# Altium schematic sheet Sheet1.SchDoc(Sheet1)
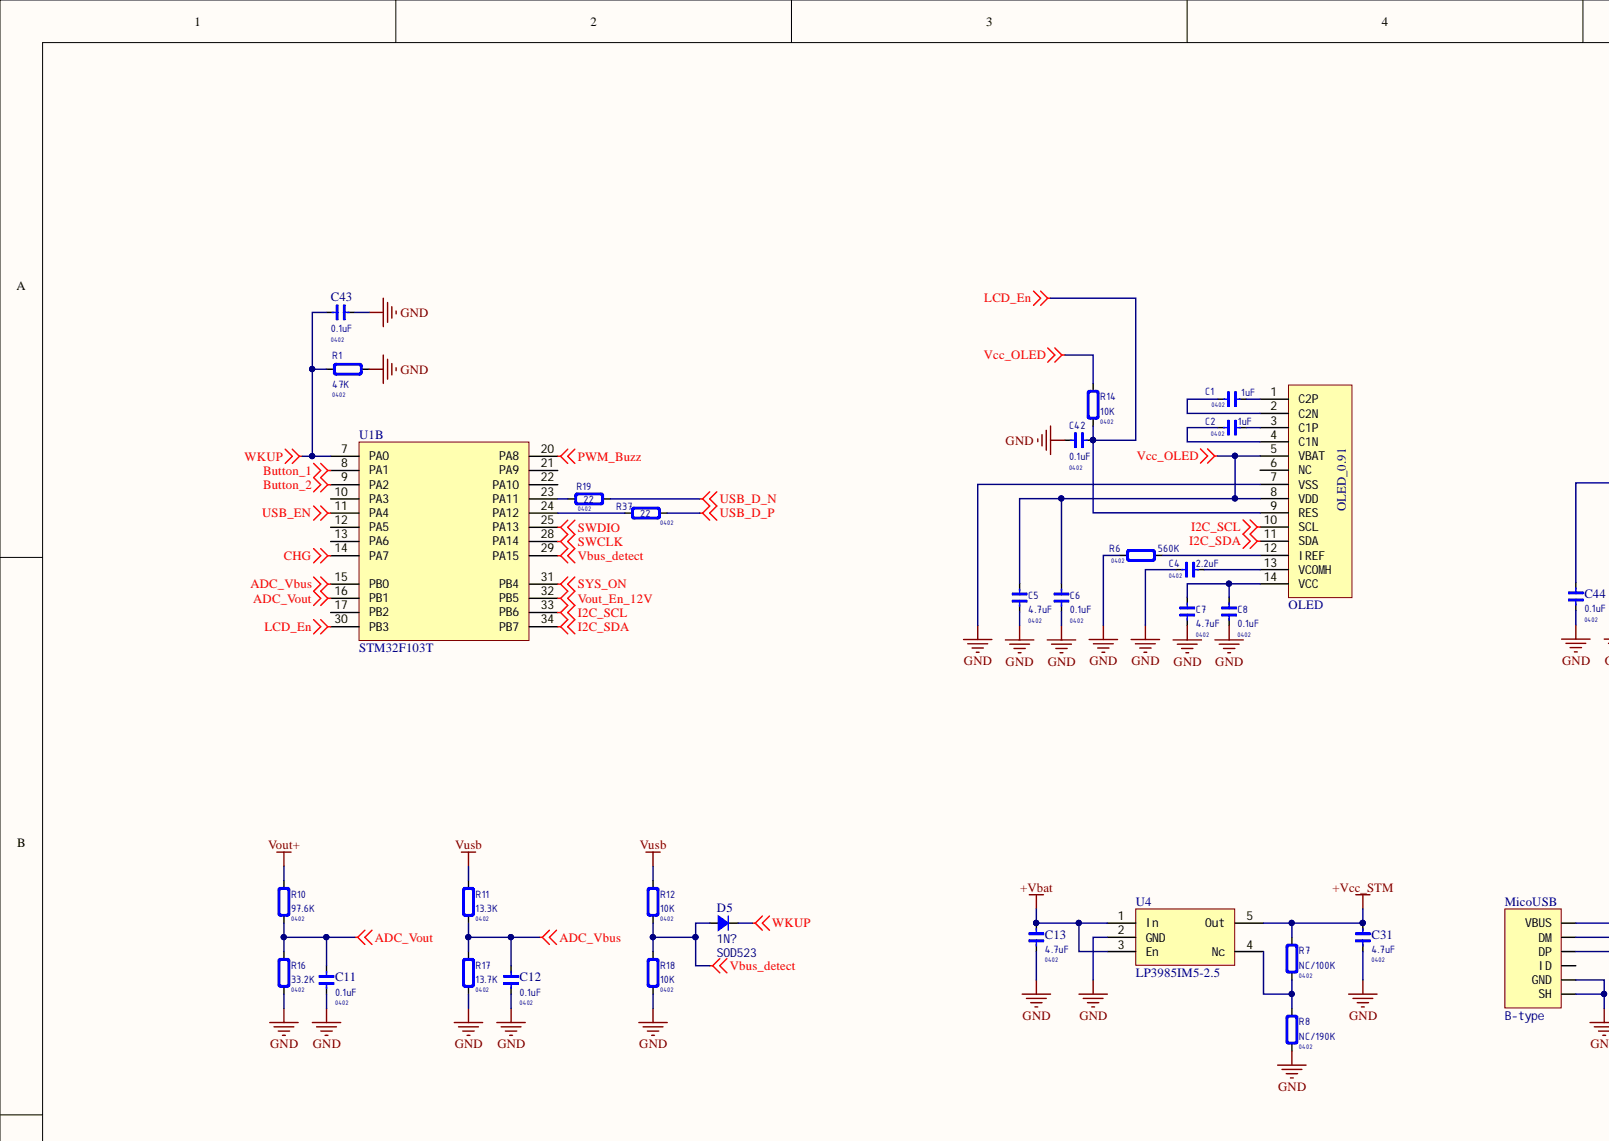
[diagram p0: Sheet1.SchDoc, top left]
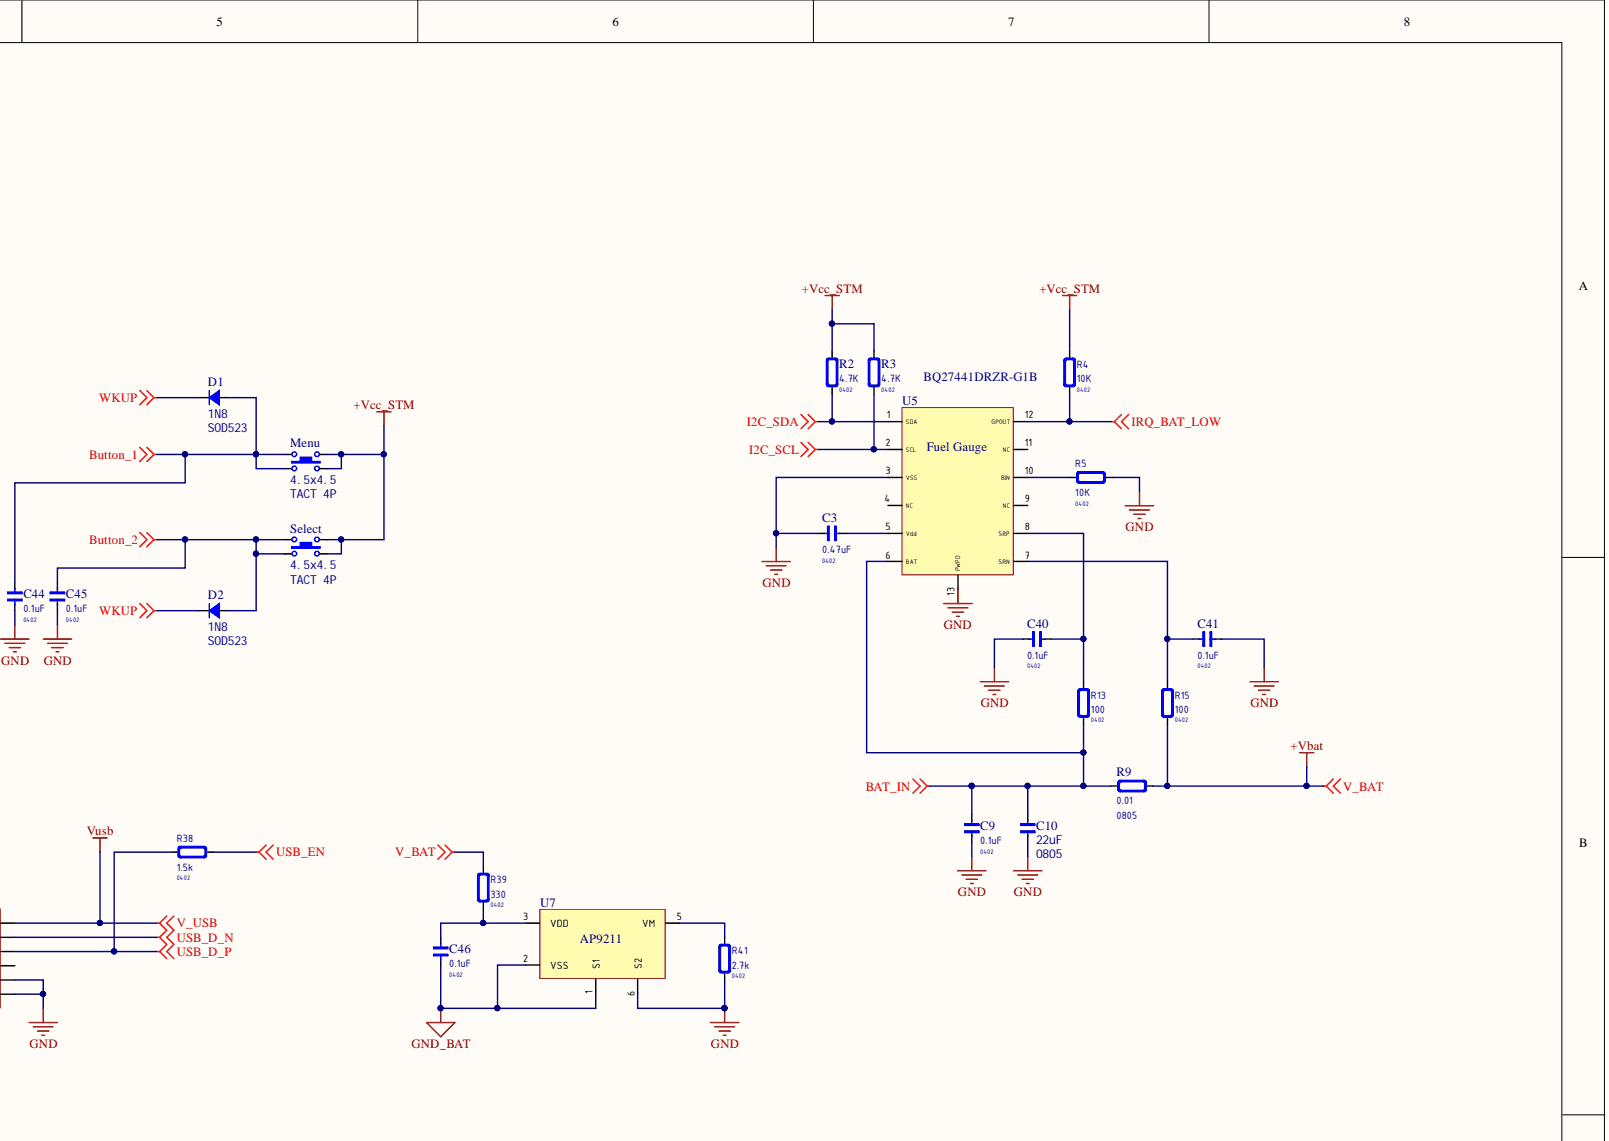
[diagram p1: Sheet1.SchDoc, top right]
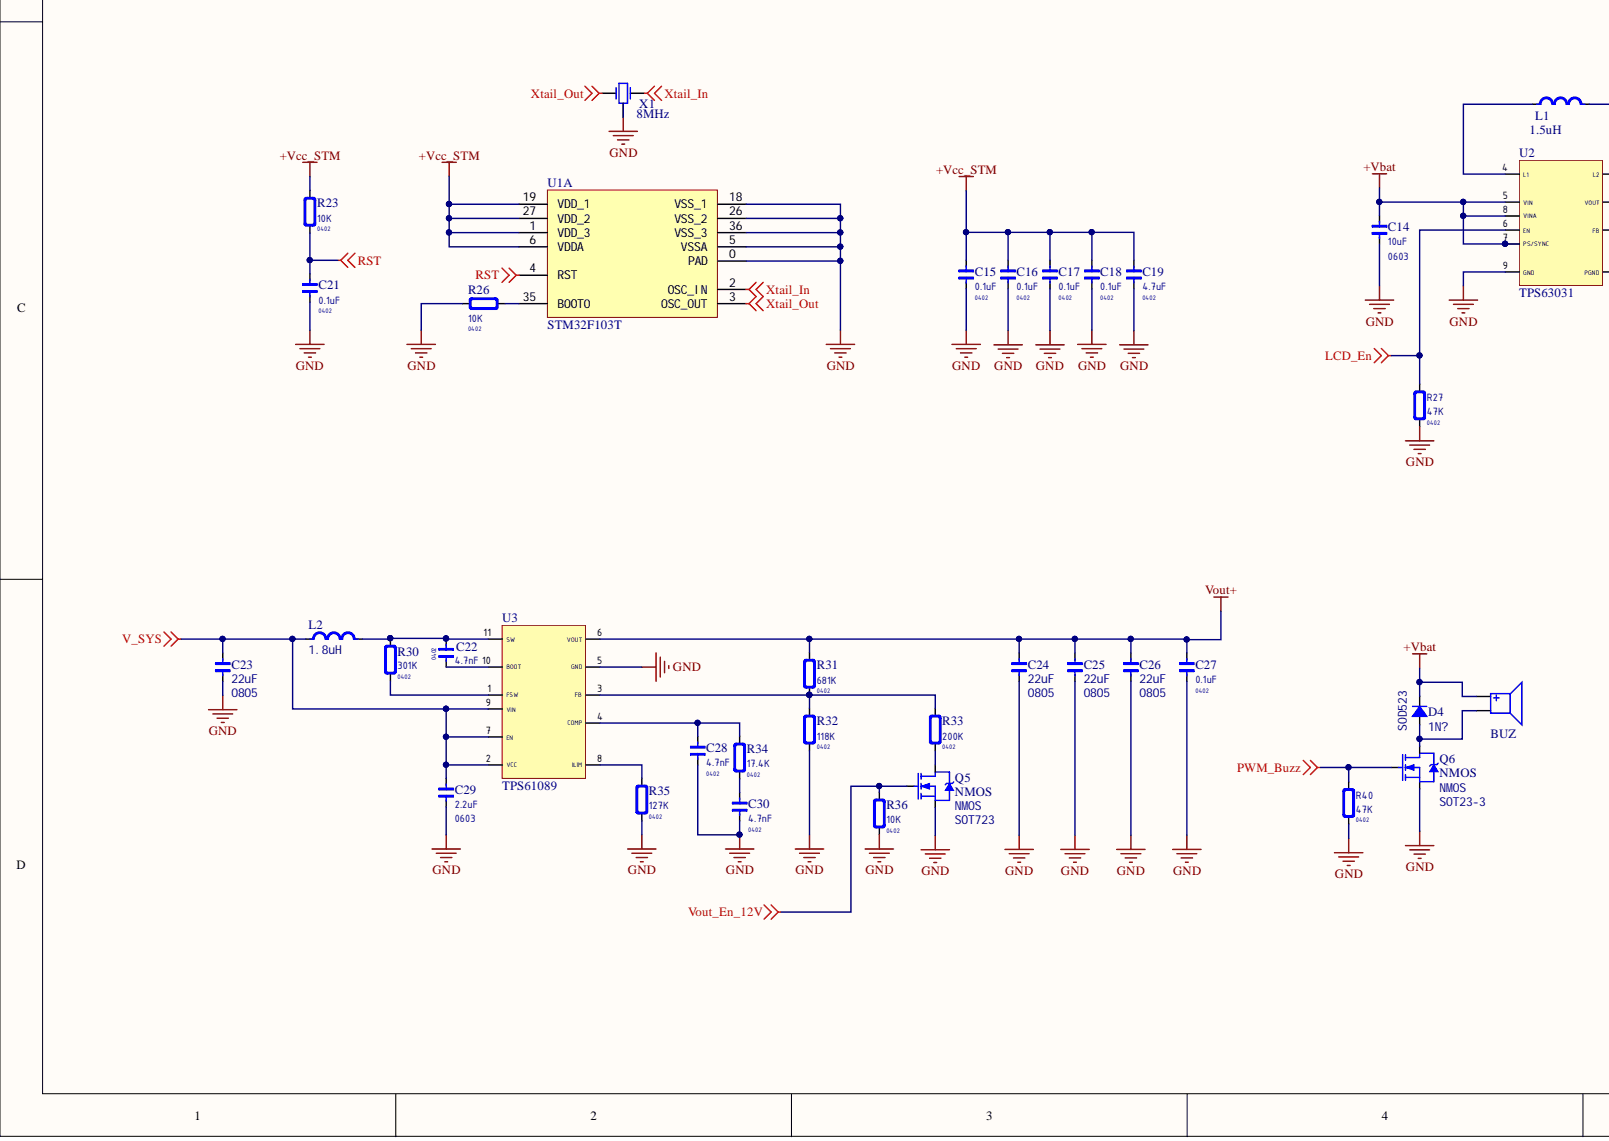
[diagram p2: Sheet1.SchDoc, bottom left]
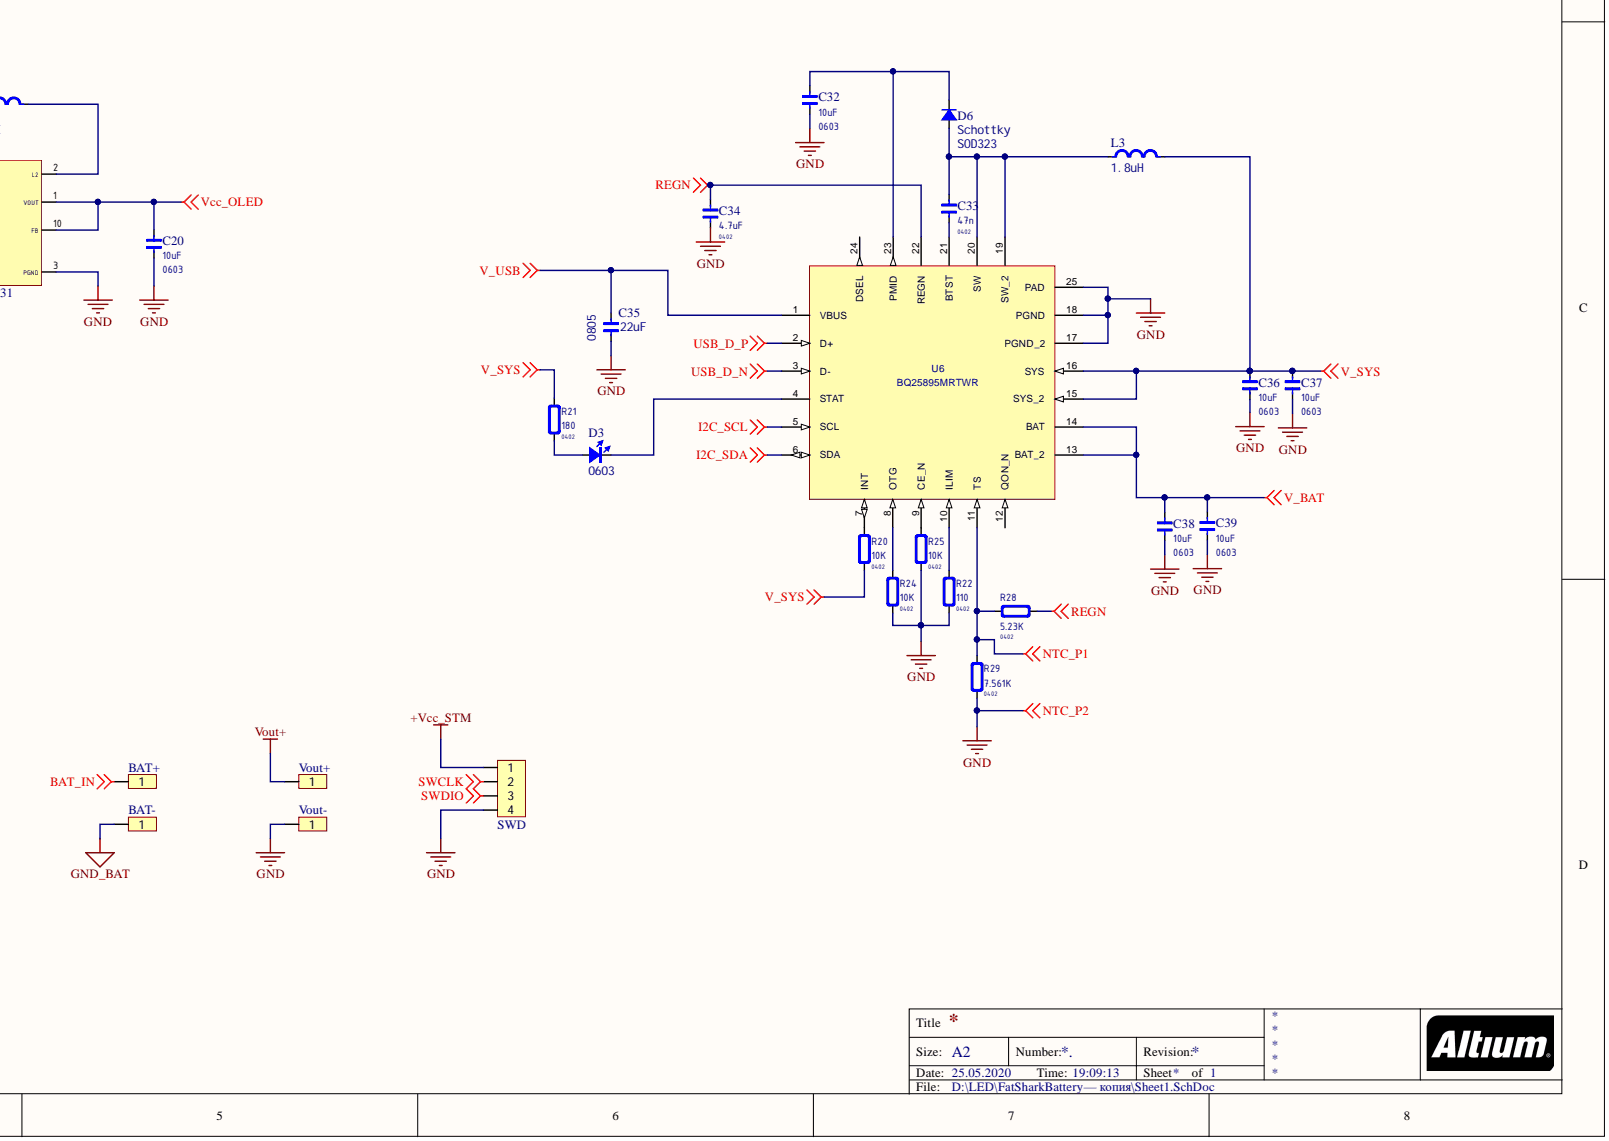
[diagram p3: Sheet1.SchDoc, bottom right]

## Parts
BAT+  HDR1x1_1.27  [HDR1X1_1.27_180]  (value not drawn)
BAT-  HDR1x1_1.27  [HDR1X1_1.27_180]  (value not drawn)
BUZ  Buzzer  [Buzzer_5x5]  (value not drawn)
C1  value 1uF  Type: 0402  [CAP_0402]  (hidden comment: C0402)
C2  value 1uF  Type: 0402  [CAP_0402]  (hidden comment: C0402)
C3  value 0.47uF  Type: 0402  [CAP_0402]  (hidden comment: C0402)
C4  value 2.2uF  Type: 0402  [CAP_0402]  (hidden comment: C0402)
C5  value 4.7uF  Type: 0402  [CAP_0402]  (hidden comment: C0402)
C6  value 0.1uF  Type: 0402  [CAP_0402]  (hidden comment: C0402)
C7  value 4.7uF  Type: 0402  [CAP_0402]  (hidden comment: C0402)
C8  value 0.1uF  Type: 0402  [CAP_0402]  (hidden comment: C0402)
C9  value 0.1uF  Type: 0402  [CAP_0402]  (hidden comment: C0402)
C10  value 22uF  Type: 0805  [CAP_0805]  (hidden comment: C0805)
C11  value 0.1uF  Type: 0402  [CAP_0402]  (hidden comment: C0402)
C12  value 0.1uF  Type: 0402  [CAP_0402]  (hidden comment: C0402)
C13  value 4.7uF  Type: 0402  [CAP_0402]  (hidden comment: C0402)
C14  value 10uF  Type: 0603  [CAP_0603]  (hidden comment: C0603)
C15  value 0.1uF  Type: 0402  [CAP_0402]  (hidden comment: C0402)
C16  value 0.1uF  Type: 0402  [CAP_0402]  (hidden comment: C0402)
C17  value 0.1uF  Type: 0402  [CAP_0402]  (hidden comment: C0402)
C18  value 0.1uF  Type: 0402  [CAP_0402]  (hidden comment: C0402)
C19  value 4.7uF  Type: 0402  [CAP_0402]  (hidden comment: C0402)
C20  value 10uF  Type: 0603  [CAP_0603]  (hidden comment: C0603)
C21  value 0.1uF  Type: 0402  [CAP_0402]  (hidden comment: C0402)
C22  value 4.7nF  Type: 0402  [CAP_0402]  (hidden comment: C0402)
C23  value 22uF  Type: 0805  [CAP_0805]  (hidden comment: C0805)
C24  value 22uF  Type: 0805  [CAP_0805]  (hidden comment: C0805)
C25  value 22uF  Type: 0805  [CAP_0805]  (hidden comment: C0805)
C26  value 22uF  Type: 0805  [CAP_0805]  (hidden comment: C0805)
C27  value 0.1uF  Type: 0402  [CAP_0402]  (hidden comment: C0402)
C28  value 4.7nF  Type: 0402  [CAP_0402]  (hidden comment: C0402)
C29  value 2.2uF  Type: 0603  [CAP_0603]  (hidden comment: C0603)
C30  value 4.7nF  Type: 0402  [CAP_0402]  (hidden comment: C0402)
C31  value 4.7uF  Type: 0402  [CAP_0402]  (hidden comment: C0402)
C32  value 10uF  Type: 0603  [CAP_0603]  (hidden comment: C0603)
C33  value 47n  Type: 0402  [CAP_0402]  (hidden comment: C0402)
C34  value 4.7uF  Type: 0402  [CAP_0402]  (hidden comment: C0402)
C35  value 22uF  Type: 0805  [CAP_0805]  (hidden comment: C0805)
C36  value 10uF  Type: 0603  [CAP_0603]  (hidden comment: C0603)
C37  value 10uF  Type: 0603  [CAP_0603]  (hidden comment: C0603)
C38  value 10uF  Type: 0603  [CAP_0603]  (hidden comment: C0603)
C39  value 10uF  Type: 0603  [CAP_0603]  (hidden comment: C0603)
C40  value 0.1uF  Type: 0402  [CAP_0402]  (hidden comment: C0402)
C41  value 0.1uF  Type: 0402  [CAP_0402]  (hidden comment: C0402)
C42  value 0.1uF  Type: 0402  [CAP_0402]  (hidden comment: C0402)
C43  value 0.1uF  [CAP_0402]  (hidden comment: C0402)
C44  value 0.1uF  Type: 0402  [CAP_0402]  (hidden comment: C0402)
C45  value 0.1uF  Type: 0402  [CAP_0402]  (hidden comment: C0402)
C46  value 0.1uF  Type: 0402  [CAP_0402]  (hidden comment: C0402)
D1  1N8  Type: SOD523  [SOD-523]
D2  1N8  Type: SOD523  [SOD-523]
D3  Type: 0603  [LED_0603_RED]  (hidden comment: color?)
D4  1N?  Type: SOD523  [SOD-523]
D5  1N?  Type: SOD523  [SOD-523]
D6  Schottky  Type: SOD323  [SOD-323]
L1  value 1.5uH  [IND_1008]  (hidden comment: H1008)
L2  value 1.8uH  [IND_6060]  (hidden comment: CD32)
L3  value 1.8uH  [IND_6060]  (hidden comment: CD32)
Menu  TACT 4P  value 4.5x4.5  [Button_SMD_4.5*4.5]
MicoUSB  Type: B-type  [USB_Micro-AB]  (hidden comment: MicroUSB)
OLED  OLED_0.91  [OLED_0.91_128x32_SSD1331]
Q5  NMOS  Type: SOT723  [SOT-723]
Q6  NMOS  Type: SOT23-3  [SOT-723]
R1  value 47K  Type: 0402  [RES_0402]  (hidden comment: R0402)
R2  value 4.7K  Type: 0402  [RES_0402]  (hidden comment: R0402)
R3  value 4.7K  Type: 0402  [RES_0402]  (hidden comment: R0402)
R4  value 10K  Type: 0402  [RES_0402]  (hidden comment: R0402)
R5  value 10K  Type: 0402  [RES_0402]  (hidden comment: R0402)
R6  value 560K  Type: 0402  [RES_0402]  (hidden comment: R0402)
R7  value NC/100K  Type: 0402  [RES_0402]  (hidden comment: R0402)
R8  value NC/190K  Type: 0402  [RES_0402]  (hidden comment: R0402)
R9  value 0.01  Type: 0805  [RES_0805]  (hidden comment: R0805)
R10  value 97.6K  Type: 0402  [RES_0402]  (hidden comment: R0402)
R11  value 13.3K  Type: 0402  [RES_0402]  (hidden comment: R0402)
R12  value 10K  Type: 0402  [RES_0402]  (hidden comment: R0402)
R13  value 100  Type: 0402  [RES_0402]  (hidden comment: R0402)
R14  value 10K  Type: 0402  [RES_0402]  (hidden comment: R0402)
R15  value 100  Type: 0402  [RES_0402]  (hidden comment: R0402)
R16  value 33.2K  Type: 0402  [RES_0402]  (hidden comment: R0402)
R17  value 13.7K  Type: 0402  [RES_0402]  (hidden comment: R0402)
R18  value 10K  Type: 0402  [RES_0402]  (hidden comment: R0402)
R19  value 22  Type: 0402  [RES_0402]  (hidden comment: R0402)
R20  value 10K  Type: 0402  [RES_0402]  (hidden comment: R0402)
R21  value 180  Type: 0402  [RES_0402]  (hidden comment: R0402)
R22  value 110  Type: 0402  [RES_0402]  (hidden comment: R0402)
R23  value 10K  Type: 0402  [RES_0402]  (hidden comment: R0402)
R24  value 10K  Type: 0402  [RES_0402]  (hidden comment: R0402)
R25  value 10K  Type: 0402  [RES_0402]  (hidden comment: R0402)
R26  value 10K  Type: 0402  [RES_0402]  (hidden comment: R0402)
R27  value 47K  Type: 0402  [RES_0402]  (hidden comment: R0402)
R28  value 5.23K  Type: 0402  [RES_0402]  (hidden comment: R0402)
R29  value 7.561K  Type: 0402  [RES_0402]  (hidden comment: R0402)
R30  value 301K  Type: 0402  [RES_0402]  (hidden comment: R0402)
R31  value 681K  Type: 0402  [RES_0402]  (hidden comment: R0402)
R32  value 118K  Type: 0402  [RES_0402]  (hidden comment: R0402)
R33  value 200K  Type: 0402  [RES_0402]  (hidden comment: R0402)
R34  value 17.4K  Type: 0402  [RES_0402]  (hidden comment: R0402)
R35  value 127K  Type: 0402  [RES_0402]  (hidden comment: R0402)
R36  value 10K  Type: 0402  [RES_0402]  (hidden comment: R0402)
R37  value 22  Type: 0402  [RES_0402]  (hidden comment: R0402)
R38  value 1.5k  Type: 0402  [RES_0402]  (hidden comment: R0402)
R39  value 330  Type: 0402  [RES_0402]  (hidden comment: R0402)
R40  value 47K  Type: 0402  [RES_0402]  (hidden comment: R0402)
R41  value 2.7k  Type: 0402  [RES_0402]  (hidden comment: R0402)
Select  TACT 4P  value 4.5x4.5  [Button_SMD_4.5*4.5]
SWD  HDR1x4_2.54  [HDR1X4_2.54_NO]  (value not drawn)
U1  STM32F103T  [QFN_P0.5_36]  (parts A,B)
U2  TPS63031  [TPS63031]
U3  TPS61089  [TPS61089]
U4  LP3985IM5-2.5  [SOT23-5]
U5  Fuel Gauge  mpn: BQ27441DRZR-G1B  [BQ27441]
U6  BQ25895MRTWR  [RTW0024H]
U7  AP9211  [DFN2030-6]
Vout+  HDR1x1_1.27  [HDR1X1_1.27_180]  (value not drawn)
Vout-  HDR1x1_1.27  [HDR1X1_1.27_180]  (value not drawn)
X1  8MHz  [CSTCE8M00G15C99]

## Nets
+Vcc_STM: C15.1 C16.1 C17.1 C18.1 C19.1 C31.1 Menu.2 Menu.4 R2.2 R3.2 R4.2 R7.1 R23.2 Select.2 Select.4 SWD.1 U1.1(VDD_3) U1.6(VDDA) U1.19(VDD_1) U1.27(VDD_2) U4.5(Out)
ADC_Vbus: C12.1 R11.2 R17.1 U1.15(PB0)
ADC_Vout: C11.1 R10.2 R16.1 U1.16(PB1)
BAT_IN: BAT+.1 C9.1 C10.2 R9.1 R13.2 U5.6(BAT)
Button_1: C44.1 D1.1 Menu.1 Menu.3 U1.8(PA1)
Button_2: C45.1 D2.1 Select.1 Select.3 U1.9(PA2)
CHG: U1.14(PA7)
GND_BAT: BAT-.1 C46.2 U7.1(S1) U7.2(VSS)
I2C_SCL: OLED.10(SCL) R3.1 U1.33(PB6) U5.2(SCL) U6.5(SCL)
I2C_SDA: OLED.11(SDA) R2.1 U1.34(PB7) U5.1(SDA) U6.6(SDA)
IRQ_BAT_LOW: R4.1 U5.12(GPOUT)
LCD_En: C42.1 OLED.9(RES) R14.1 R27.1 U1.30(PB3) U2.6(EN)
NTC_P1: R28.1 R29.1 U6.11(TS)
NTC_P2: C3.2 C4.1 C5.1 C6.1 C7.1 C8.1 C9.2 C10.1 C11.2 C12.2 C13.2 C14.1 C15.2 C16.2 C17.2 C18.2 C19.2 C20.1 C21.1 C23.1 C24.1 C25.1 C26.1 C27.2 C28.1 C29.1 C30.1 C31.2 C32.1 C34.2 C35.1 C36.1 C37.1 C38.1 C39.1 C40.1 C41.2 C42.2 C43.2 C44.2 C45.2 MicoUSB.0(SH) MicoUSB.5(GND) OLED.7(VSS) Q5.2 Q6.2 R1.2 R5.2 R6.1 R8.2 R16.2 R17.2 R18.2 R22.2 R24.2 R25.2 R26.2 R27.2 R29.2 R32.1 R35.1 R36.1 R40.2 R41.2 SWD.4 U1.0(PAD) U1.5(VSSA) U1.18(VSS_1) U1.26(VSS_2) U1.36(VSS_3) U2.3(PGND) U2.9(GND) U3.5(GND) U4.2(GND) U5.3(VSS) U5.13(PWPD) U6.17(PGND_2) U6.18(PGND) U6.25(PAD) U7.6(S2) Vout-.1 X1.3
PWM_Buzz: Q6.1 R40.1 U1.20(PA8)
REGN: C34.1 R28.2 U6.22(REGN)
RST: C21.2 R23.1 U1.4(RST)
SWCLK: SWD.2 U1.28(PA14)
SWDIO: SWD.3 U1.25(PA13)
SYS_ON: U1.31(PB4)
USB_D_N: MicoUSB.2(DM) R19.2 U6.3(D-)
USB_D_P: MicoUSB.3(DP) R37.2 R38.1 U6.2(D+)
USB_EN: R38.2 U1.11(PA4)
V_BAT: BUZ.P C13.1 C14.2 C38.2 C39.2 D4.2 R9.2 R15.2 R39.1 U2.5(VIN) U2.7(PS/SYNC) U2.8(VINA) U4.1(In) U4.3(En) U6.13(BAT_2) U6.14(BAT)
V_SYS: C23.2 C29.2 C36.2 C37.2 L2.1 L3.2 R20.2 R21.1 U3.2(VCC) U3.7(EN) U3.9(VIN) U6.15(SYS_2) U6.16(SYS)
V_USB: C35.2 MicoUSB.1(VBUS) R11.1 R12.1 U6.1(VBUS)
Vbus_detect: D5.1 R12.2 R18.1 U1.29(PA15)
Vcc_OLED: C5.2 C6.2 C20.2 OLED.5(VBAT) OLED.8(VDD) R14.2 U2.1(VOUT) U2.10(FB)
Vout+: C24.2 C25.2 C26.2 C27.1 R10.1 R31.2 U3.6(VOUT) Vout+.1
Vout_En_12V: Q5.1 R36.2 U1.32(PB5)
WKUP: C43.1 D1.2 D2.2 D5.2 R1.1 U1.7(PA0)
Xtail_In: U1.2(OSC_IN) X1.2
Xtail_Out: U1.3(OSC_OUT) X1.1
NetBUZ_N: BUZ.N D4.1 Q6.3
NetC1_1: C1.1 OLED.2(C2N)
NetC1_2: C1.2 OLED.1(C2P)
NetC2_1: C2.1 OLED.4(C1N)
NetC2_2: C2.2 OLED.3(C1P)
NetC3_1: C3.1 U5.5(Vdd)
NetC4_2: C4.2 OLED.13(VCOMH)
NetC7_2: C7.2 C8.2 OLED.14(VCC)
NetC22_1: C22.1 U3.10(BOOT)
NetC22_2: C22.2 L2.2 R30.2 U3.11(SW)
NetC28_2: C28.2 R34.2 U3.4(COMP)
NetC30_2: C30.2 R34.1
NetC32_2: C32.2 D6.2 U6.23(PMID)
NetC33_1: C33.1 D6.1 L3.1 U6.19(SW_2) U6.20(SW)
NetC33_2: C33.2 U6.21(BTST)
NetC40_2: C40.2 R13.1 U5.8(SRP)
NetC41_1: C41.1 R15.1 U5.7(SRN)
NetC46_1: C46.1 R39.2 U7.3(VDD)
NetD3_1: D3.1 R21.2
NetD3_2: D3.2 U6.4(STAT)
NetL1_1: L1.1 U2.4(L1)
NetL1_2: L1.2 U2.2(L2)
NetOLED_12: OLED.12(IREF) R6.2
NetQ5_3: Q5.3 R33.1
NetR5_1: R5.1 U5.10(BIN)
NetR7_2: R7.2 R8.1 U4.4(Nc)
NetR19_1: R19.1 U1.23(PA11)
NetR20_1: R20.1 U6.7(INT)
NetR22_1: R22.1 U6.10(ILIM)
NetR24_1: R24.1 U6.8(OTG)
NetR25_1: R25.1 U6.9(CE_N)
NetR26_1: R26.1 U1.35(BOOT0)
NetR30_1: R30.1 U3.1(FSW)
NetR31_1: R31.1 R32.2 R33.2 U3.3(FB)
NetR35_2: R35.2 U3.8(ILIM)
NetR37_1: R37.1 U1.24(PA12)
NetR41_1: R41.1 U7.5(VM)

Not connected: MicoUSB 4(ID); OLED 6(NC); U1 10(PA3) 12(PA5) 13(PA6) 17(PB2) 21(PA9) 22(PA10); U5 4(NC) 9(NC) 11(NC); U6 12(QON_N) 24(DSEL)

## Library data of the parts
BAT+ BAT- Vout+ Vout- = HDR1x1_1.27: Header; Value: 1.27; Type: DIP 1x1; Library Name: SCH_ConnectorHDR.SchLib; Component Kind: Standard
C1-C9 C11-C13 C15-C19 C22 C27 C28 C30 C31 C33 C34 C40-C42 C44-C46 = Cap_0402: Capacitor; Specification: X7R 50V; Library Name: SCH_Passive.SchLib; Component Kind: Standard
C10 C23-C26 C35 = Cap_0805: Capacitor; MPN: 0805X226M250NT; Specification: 25V; Link: https://lcsc.com/product-detail/Multilayer-Ceramic-Capacitors-MLCC-SMD-SMT_FH-Guangdong-Fenghua-Advanced-Tech-0805X226M250NT_C129303.html; Library Name: SCH_Passive.SchLib; Component Kind: Standard
C14 C20 C29 C32 C36-C39 = Cap_0603: Capacitor; Specification: X7R 50V; Library Name: SCH_Passive.SchLib; Component Kind: Standard
C21 = Cap_0402: Capacitor; MPN: 0402B104K160CT; Specification: X7R 50V; Link: https://lcsc.com/product-detail/Multilayer-Ceramic-Capacitors-MLCC-SMD-SMT_Walsin-Tech-Corp-0402B104K160CT_C83056.html; Library Name: SCH_Passive.SchLib; Component Kind: Standard
C43 = Cap_0402: Capacitor; Type: 0402; Specification: X7R 50V; Library Name: SCH_Passive.SchLib; Component Kind: Standard
D1 D2 D4 D5 = Diode_SOD523: Diode; Library Name: SCH_Active.SchLib; Component Kind: Standard
  D1 D2: Value: 1N8
  D4 D5: Value: 1N?
D3 = LED_0603: LED; Value: color?; Library Name: SCH_Active.SchLib; Component Kind: Standard
D6 = Diode_SOD323: Diode; MPN: PMEG2020AEA; Value: Schottky; Link: https://lcsc.com/product-detail/Schottky-Barrier-Diodes-SBD_Nexperia-PMEG2020AEA-115_C389354.html; Library Name: SCH_Active.SchLib; Component Kind: Standard
L1 = Ind_1008: Inductor 1.5uH 435mA; mpn: NLCV25T-1R5M-EF; Manufacturer: TDK; Specification: ±5% 435mA; Link: https://lcsc.com/product-detail/Inductors-SMD_TDK_NLCV25T-1R5M-EF_TDK-NLCV25T-1R5M-EF_C250216.html; Library Name: SCH_Passive.SchLib; Component Kind: Standard
L2 L3 = Ind_6060: Inductor; mpn: SNR6045K-1R8N; Manufacturer: 3L COILS; Type: 4040; Specification: ±5%; Link: https://lcsc.com/product-detail/Others_3L-COILS-SNR6045K-1R8N_C326333.html; Library Name: SCH_Passive.SchLib; Component Kind: Standard
Menu Select = Button: type: TACT 4P; Library Name: SCH_Switch.SchLib; Description: Button; Component Kind: Standard
MicoUSB = USB_Micro: USB; Library Name: SCH_USB.SchLib; Component Kind: Standard
OLED = OLED_0.91: OLED 0.91"128x32; Library Name: SCH_Module.SchLib; Component Kind: Standard
Q5 Q6 = MOSFET_N_SOT23: MOSFET; Value: NMOS; Library Name: SCH_Active.SchLib; Component Kind: Standard
R1-R6 R13 R15-R18 R20-R36 R38-R41 = Res_0402: Resistor; Specification: ±5%; Library Name: SCH_Passive.SchLib; Component Kind: Standard
  R23 R26: Link: https://lcsc.com/product-detail/Chip-Resistor-Surface-Mount_10KR-1002-1_C60490.html
R7 R8 R10-R12 R14 R19 R37 = Res_0402: Resistor; Specification: ±1%; Library Name: SCH_Passive.SchLib; Component Kind: Standard
R9 = Res_0805: Current Sense Resistors; mpn: FBF05FTPR010-00L; Specification: ±1%; Link: https://lcsc.com/product-detail/Low-Resistors-Current-Sense-Resistors-Surface-Mount_PSA-Prosperity-Dielectrics-FBF05FTPR010-00L_C382280.html; Library Name: SCH_Passive.SchLib; Component Kind: Standard
SWD = HDR1x4_2.54: Header; Library Name: SCH_ConnectorHDR.SchLib; Component Kind: Standard
U1 = STM32F103T: MCU; Type: QFN36 P0.5; Library Name: SCH_IntegratedCircuit.SchLib; Component Kind: Standard
U2 = TPS63031: DC/DC Step-Up/Step-Down; mpn: TPS63031DSKR; Manufacturers: Texas Instruments; Value: DC/DC; Type: QFN-10; Link: https://lcsc.com/product-detail/DC-DC-Converters_Texas-Instruments-TPS63031DSKR_C15516.html; Library Name: SCH_DC.SchLib; Component Kind: Standard
U3 = TPS61089x: Step-Up Positive Adjustable 1 7A 200kHz ~ 2.2MHz VQFN-HR DC-DC Converters RoHS; mpn: TPS61089RNRR; Manufacturers: Texas Instruments; Value: DC/DC; Type: VQFN-HR; Link: https://lcsc.com/product-detail/DC-DC-Converters_Texas-Instruments-TPS61089RNRR_C165129.html; Library Name: SCH_DC.SchLib; Component Kind: Standard
U4 = TPS61322xx: LDO 2.5V; Manufactures: Texas Instruments; Value: 2.5V; Type: LDO SOT23-5; mpn: LP3985IM5-2.5; Link: https://lcsc.com/product-detail/Low-Dropout-Regulators-LDO_Texas-Instruments_LP3985IM5-2-5-NOPB_Texas-Instruments-Texas-Instruments-LP3985IM5-2-5-NOPB_C201609.html; Library Name: SCH_IntegratedCircuit.SchLib; Component Kind: Standard
U5 = BQ27441: System-Side Fuel Gauge  i2c; Manufacturers: Texas Instruments; Value: Battery Management; Type: SON-12; Link: https://lcsc.com/product-detail/PMIC-Battery-Management_Texas-Instruments-Texas-Instruments-BQ27441DRZR-G1B_C473374.html; Library Name: SCH_DC.SchLib; Component Kind: Standard
U6 = BQ25895MRTWR: IC NVDC CHARGER I2C 24WQFN; Manufacturer: Texas Instruments; Value: BQ25895MRTWR; Type: SMT; mpn: BQ25895MRTWR; Link: https://www.digikey.com/product-detail/en/texas-instruments/BQ25895RTWR/296-44345-2-ND/5214018; Library Name: SCH_IntegratedCircuit.SchLib; Component Kind: Standard
U7 = AP9211: Battery Protection ICs; mpn: AP9211SA-AB-HAC-7; Type: Battery Protection ICs; link: https://lcsc.com/product-detail/Battery-Protection-ICs_Diodes-Incorporated-AP9211SA-AB-HAC-7_C460546.html; Library Name: SCH_Active.SchLib; Component Kind: Standard
X1 = Xtal: Ceramic Resonators; Manufacture: Murata Electronics; Value: 8MHz; MPN: CSTCE8M00G15C99; Link: https://lcsc.com/product-detail/Ceramic-Resonators_Murata-Electronics-CSTCE8M00G15C99-R0_C110614.html; Library Name: SCH_Oscillator.SchLib; Component Kind: Standard
BUZ = Buzzer: Library Name: SCH_Module.SchLib; Description: Buzzer; Component Kind: Standard
